# Altium schematic sheet sch1.SchDoc
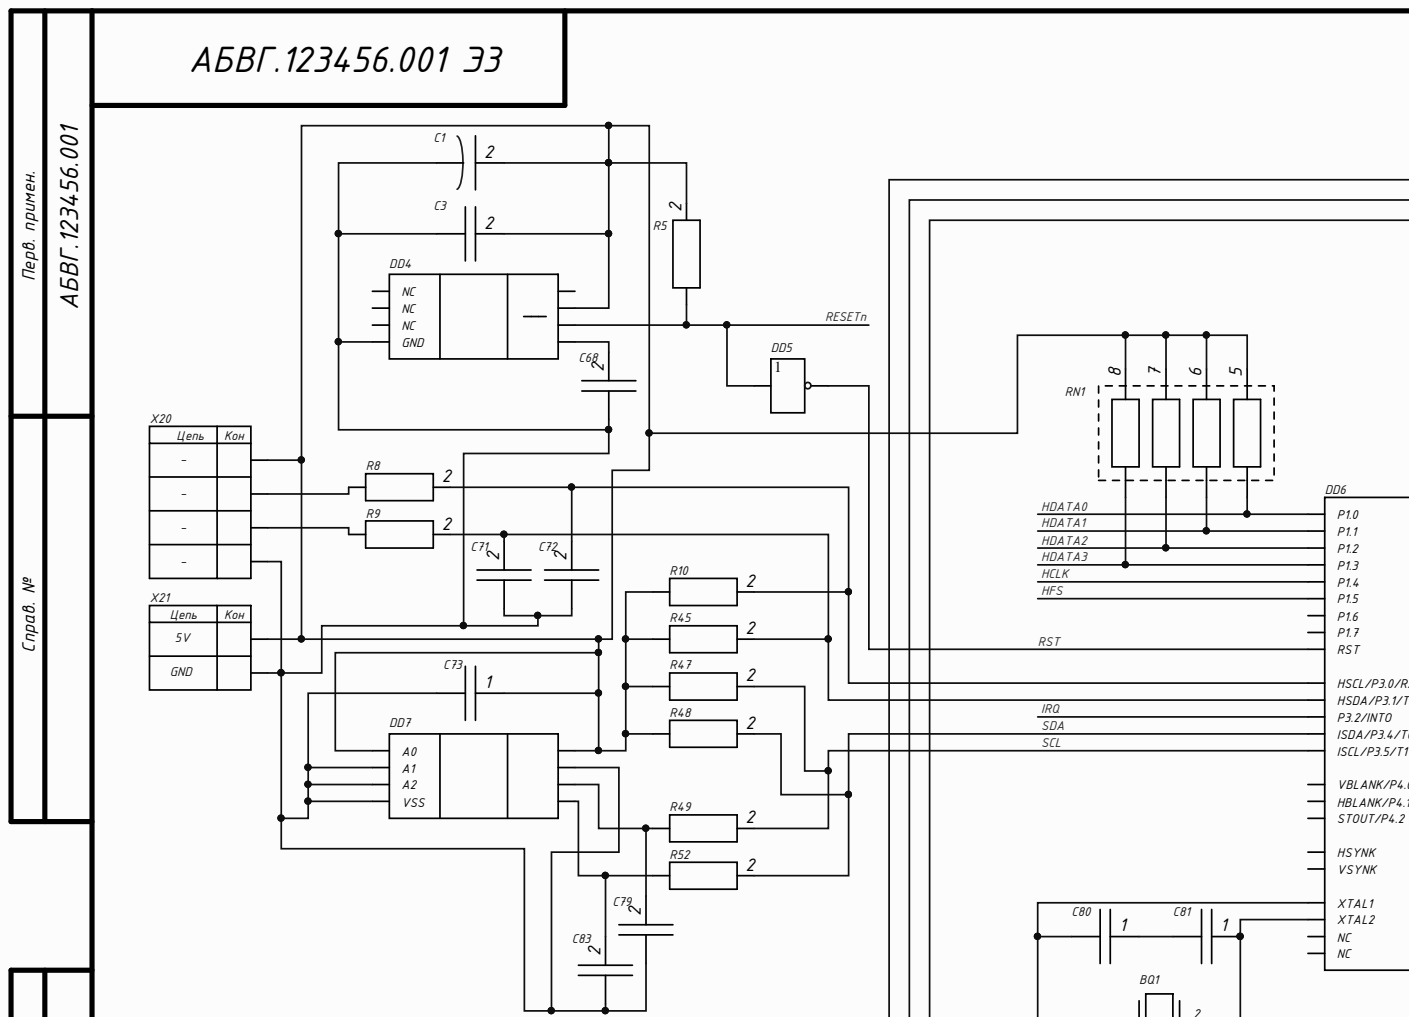
[diagram p0: sch1.SchDoc, top left]
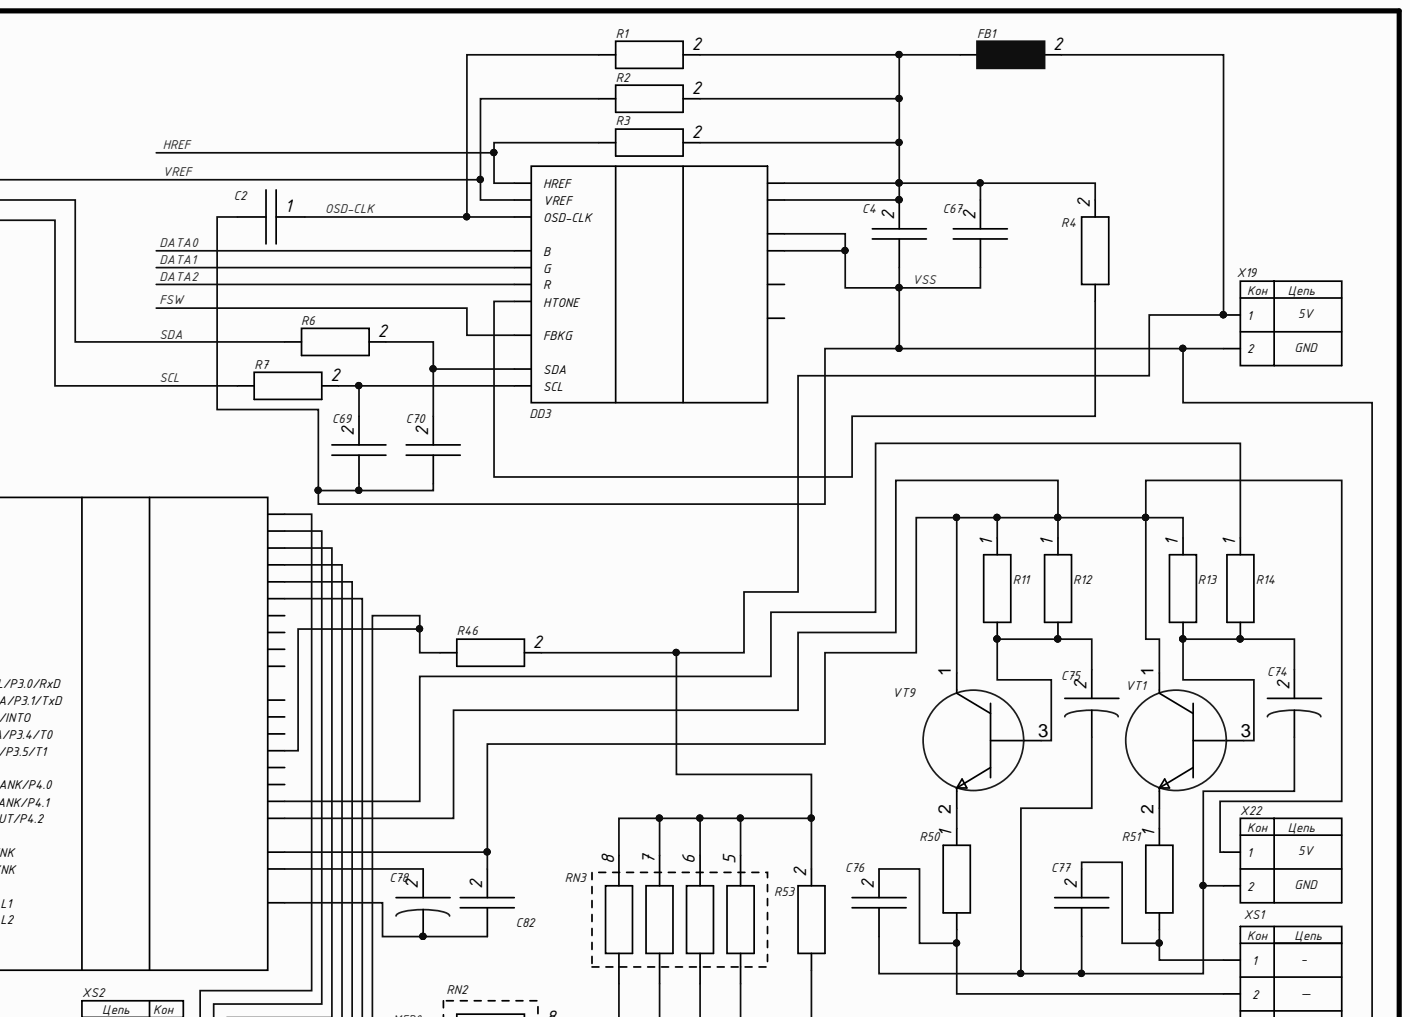
[diagram p1: sch1.SchDoc, top right]
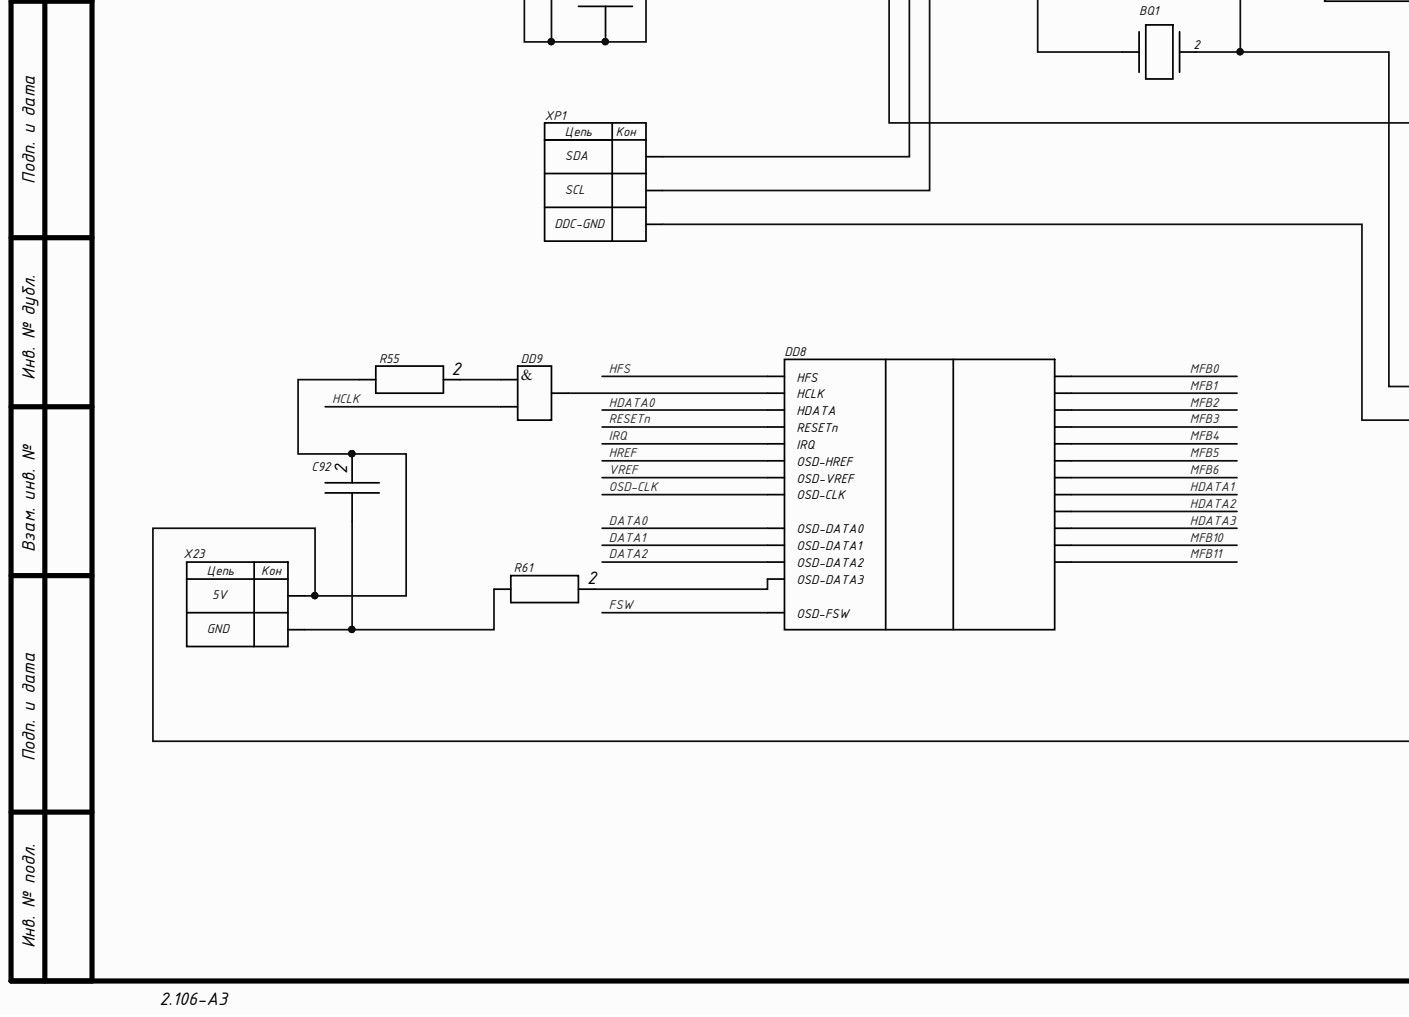
[diagram p2: sch1.SchDoc, bottom left]
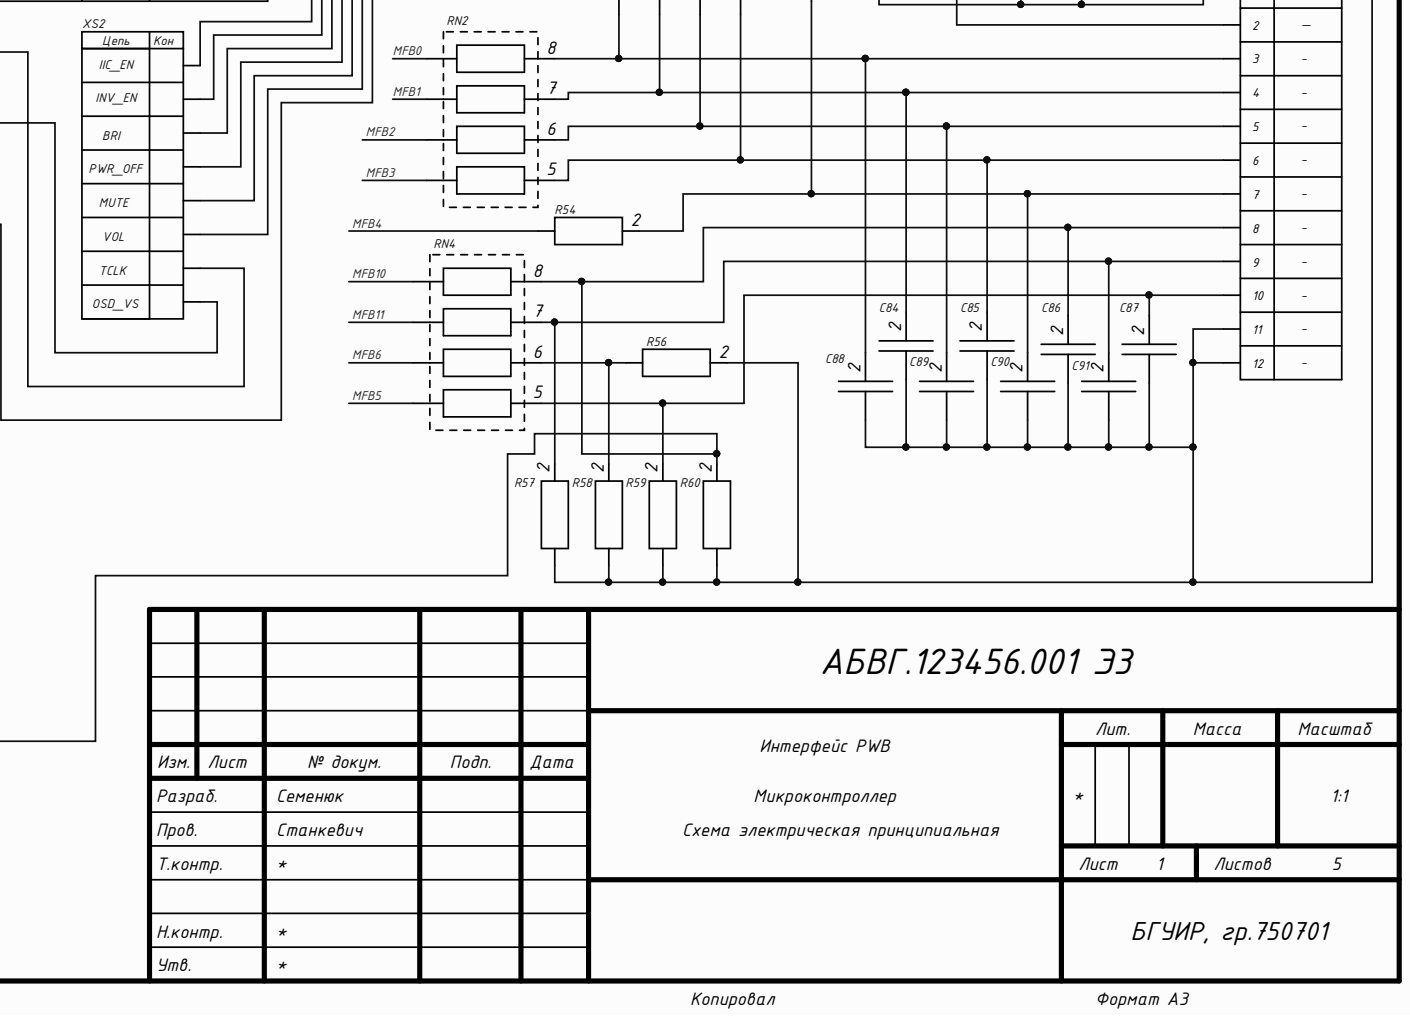
[diagram p3: sch1.SchDoc, bottom right]

## Parts
BQ1  Crystal  [Crystal]  (value not drawn)
C1  Capacitor Duga  [Capacitor 0805]  (value not drawn)
C2  Capacitor  [Capacitor 0805]  (value not drawn)
C3  Capacitor  [Capacitor 0805]  (value not drawn)
C4  Capacitor  [Capacitor 0805]  (value not drawn)
C67  Capacitor  [Capacitor 0805]  (value not drawn)
C68  Capacitor  [Capacitor 0805]  (value not drawn)
C69  Capacitor  [Capacitor 0805]  (value not drawn)
C70  Capacitor  [Capacitor 0805]  (value not drawn)
C71  Capacitor  [Capacitor 0805]  (value not drawn)
C72  Capacitor  [Capacitor 0805]  (value not drawn)
C73  Capacitor  [Capacitor 0805]  (value not drawn)
C74  Capacitor Duga  [Capacitor 0805]  (value not drawn)
C75  Capacitor Duga  [Capacitor 0805]  (value not drawn)
C76  Capacitor  [Capacitor 0805]  (value not drawn)
C77  Capacitor  [Capacitor 0805]  (value not drawn)
C78  Capacitor Duga  [Capacitor 0805]  (value not drawn)
C79  Capacitor  [Capacitor 0805]  (value not drawn)
C80  Capacitor  [Capacitor 0805]  (value not drawn)
C81  Capacitor  [Capacitor 0805]  (value not drawn)
C82  Capacitor  [Capacitor 0805]  (value not drawn)
C83  Capacitor  [Capacitor 0805]  (value not drawn)
C84  Capacitor  [Capacitor 0805]  (value not drawn)
C85  Capacitor  [Capacitor 0805]  (value not drawn)
C86  Capacitor  [Capacitor 0805]  (value not drawn)
C87  Capacitor  [Capacitor 0805]  (value not drawn)
C88  Capacitor  [Capacitor 0805]  (value not drawn)
C89  Capacitor  [Capacitor 0805]  (value not drawn)
C90  Capacitor  [Capacitor 0805]  (value not drawn)
C91  Capacitor  [Capacitor 0805]  (value not drawn)
C92  Capacitor  [Capacitor 0805]  (value not drawn)
DD3  I311  [I311]  (value not drawn)
DD4  M51953A  [M51953A]  (value not drawn)
DD5  Inverter  [Inverter]  (value not drawn)
DD6  MTV312M64  [MTV312M64]  (value not drawn)
DD7  24LC32  [24LC32]  (value not drawn)
DD8  gmZAN1  [GMZAN1]  (value not drawn)
DD9  Сonjuncture  [Conjuncture]  (value not drawn)
FB1  Ferrite_bead  [FERRITE BEAD]  (value not drawn)
R1  Resistor  [Resistor 1206]  (value not drawn)
R2  Resistor  [Resistor 1206]  (value not drawn)
R3  Resistor  [Resistor 1206]  (value not drawn)
R4  Resistor  [Resistor 1206]  (value not drawn)
R5  Resistor  [Resistor 1206]  (value not drawn)
R6  Resistor  [Resistor 1206]  (value not drawn)
R7  Resistor  [Resistor 1206]  (value not drawn)
R8  Resistor  [Resistor 1206]  (value not drawn)
R9  Resistor  [Resistor 1206]  (value not drawn)
R10  Resistor  [Resistor 1206]  (value not drawn)
R11  Resistor  [Resistor 1206]  (value not drawn)
R12  Resistor  [Resistor 1206]  (value not drawn)
R13  Resistor  [Resistor 1206]  (value not drawn)
R14  Resistor  [Resistor 1206]  (value not drawn)
R45  Resistor  [Resistor 1206]  (value not drawn)
R46  Resistor  [Resistor 1206]  (value not drawn)
R47  Resistor  [Resistor 1206]  (value not drawn)
R48  Resistor  [Resistor 1206]  (value not drawn)
R49  Resistor  [Resistor 1206]  (value not drawn)
R50  Resistor  [Resistor 1206]  (value not drawn)
R51  Resistor  [Resistor 1206]  (value not drawn)
R52  Resistor  [Resistor 1206]  (value not drawn)
R53  Resistor  [Resistor 1206]  (value not drawn)
R54  Resistor  [Resistor 1206]  (value not drawn)
R55  Resistor  [Resistor 1206]  (value not drawn)
R56  Resistor  [Resistor 1206]  (value not drawn)
R57  Resistor  [Resistor 1206]  (value not drawn)
R58  Resistor  [Resistor 1206]  (value not drawn)
R59  Resistor  [Resistor 1206]  (value not drawn)
R60  Resistor  [Resistor 1206]  (value not drawn)
R61  Resistor  [Resistor 1206]  (value not drawn)
RN1  RN  [RN]
RN2  RN  [RN]
RN3  RN  [RN]
RN4  RN  [RN]
VT1  Transistor 2_1  [Transistor 1]  (value not drawn)
VT9  Transistor 2_1  [Transistor 1]  (value not drawn)
X19  5V-GND_1  [VCC-GND]  (value not drawn)
X20  Header 4  [J102]  (value not drawn)
X21  5V-GND  [VCC-GND]  (value not drawn)
X22  5V-GND_1  [VCC-GND]  (value not drawn)
X23  5V-GND  [VCC-GND]  (value not drawn)
XP1  All pins_1  [All pins]  (value not drawn)
XS1  Header 12  [All pins]  (value not drawn)
XS2  All pins  [All pins]  (value not drawn)

## Nets
GND: DD5.3 DD9.3
VCC: DD5.5 DD9.5
DATA0: DD3.13(B) DD8.118(OSD-DATA0)
DATA1: DD3.14(G) DD8.119(OSD-DATA1)
DATA2: DD3.15(R) DD8.120(OSD-DATA2)
FSW: DD3.12(FBKG) DD8.122(OSD-FSW)
HCLK: DD6.22(P1.4) DD9.2
HDATA0: DD6.17(P1.0) DD8.99(HDATA) RN1.4
HDATA1: DD6.18(P1.1) DD8.105 RN1.3
HDATA2: DD6.20(P1.2) DD8.104 RN1.2
HDATA3: DD6.21(P1.3) DD8.102 RN1.1
HFS: DD6.23(P1.5) DD8.98(HFS)
HREF: DD3.5(HREF) DD8.115(OSD-HREF) R3.1
IRQ: DD6.19(P3.2/INTO) DD8.101(IRQ)
MFB0: DD8.113 RN2.1
MFB1: DD8.112 RN2.2
MFB2: DD8.111 RN2.3
MFB3: DD8.110 RN2.4
MFB4: DD8.109 R54.1
MFB5: DD8.107 RN4.4
MFB6: DD8.106 RN4.3
MFB10: DD8.124 RN4.1
MFB11: DD8.123 RN4.2
OSD-CLK: C2.1 DD3.2(OSD-CLK) DD8.117(OSD-CLK) R1.1
RESETn: DD4.6 DD5.2 DD8.100(RESETn) R5.1
RST: DD5.4 DD6.7(RST)
SCL: DD6.14(ISCL/P3.5/T1) R7.1 R47.2 R49.2 XP1.2
SDA: DD6.13(ISDA/P3.4/T0) R6.1 R48.2 R52.2 XP1.1
VREF: DD3.10(VREF) DD8.116(OSD-VREF) R2.1 XS2.8
VSS: C2.2 C4.1 C67.1 C69.1 C70.1 C84.1 C85.1 C86.1 C87.1 C88.1 C89.1 C90.1 C91.1 DD3.1 DD3.16 R56.2 R57.1 R58.1 R59.1 R60.1 X19.2 XS1.11 XS1.12
NetBQ1_1: BQ1.1 C80.2 DD6.12(XTAL1)
NetBQ1_2: BQ1.2 C81.1 DD6.11(XTAL2) XS2.7
NetC1_1: C1.1 C3.1 C68.1 C71.1 C72.1 C73.2 C79.1 C83.1 DD4.4(GND) DD7.2(A1) DD7.3(A2) DD7.4(VSS) DD7.7 X20.4 X21.2
NetC1_2: C1.2 C3.2 C73.1 DD4.7 DD7.1(A0) DD7.8 R5.2 R10.1 R45.1 R47.1 R48.1 RN1.5 RN1.6 RN1.7 RN1.8 X20.1 X21.1
NetC4_2: C4.2 C67.2 DD3.4 DD3.9 FB1.1 R1.2 R2.2 R3.2 R4.2
NetC68_2: C68.2 DD4.5
NetC69_2: C69.2 DD3.8(SCL) R7.2
NetC70_2: C70.2 DD3.7(SDA) R6.2
NetC71_2: C71.2 DD6.28(HSDA/P3.1/TxD) R9.2 R45.2
NetC72_2: C72.2 DD6.29(HSCL/P3.0/RxD) R8.2 R10.2
NetC74_1: C74.1 C75.1 C76.1 C77.1 X22.2
NetC74_2: C74.2 R13.2 R14.2 VT1.3
NetC75_2: C75.2 R11.2 R12.2 VT9.3
NetC76_2: C76.2 R50.2 XS1.2
NetC77_2: C77.2 R51.2 XS1.1
NetC78_1: C78.1 C82.1 DD6.10
NetC78_2: C78.2 DD6.4
NetC79_2: C79.2 DD7.6 R49.1
NetC80_1: C80.1 C81.2
NetC82_2: C82.2 DD6.8 DD6.33 R11.1 R12.1 R13.1 VT1.1 VT9.1 X22.1
NetC83_2: C83.2 DD7.5 R52.1
NetC84_2: C84.2 RN2.7 RN3.2 XS1.4
NetC85_2: C85.2 RN2.5 RN3.4 XS1.6
NetC86_2: C86.2 C92.2 R55.1 R60.2 RN4.8 X23.1 XS1.8
NetC87_2: C87.2 R59.2 RN4.5 XS1.10
NetC88_2: C88.2 RN2.8 RN3.1 XS1.3
NetC89_2: C89.2 RN2.6 RN3.3 XS1.5
NetC90_2: C90.2 R53.1 R54.2 XS1.7
NetC91_2: C91.2 R57.2 RN4.7 XS1.9
NetC92_1: C92.1 R61.1 X23.2
NetDD3_11: DD3.11(HTONE) R4.1
NetDD6_1: DD6.1 XS2.3
NetDD6_2: DD6.2 XS2.2
NetDD6_3: DD6.3 XS2.1
NetDD6_9: DD6.9 R46.1 XP1.3
NetDD6_32: DD6.32 R14.1
NetDD6_40: DD6.40 XS2.6
NetDD6_41: DD6.41 XS2.5
NetDD6_42: DD6.42 XS2.4
NetDD8_103: DD8.103(HCLK) DD9.4
NetDD8_121: DD8.121(OSD-DATA3) R61.2
NetDD9_1: DD9.1 R55.2
NetFB1_2: FB1.2 R46.2 R53.2 RN3.5 RN3.6 RN3.7 RN3.8 X19.1
NetR8_1: R8.1 X20.2
NetR9_1: R9.1 X20.3
NetR50_1: R50.1 VT9.2
NetR51_1: R51.1 VT1.2
NetR56_1: R56.1 R58.2 RN4.6

Not connected: DD3 3 6; DD4 1(NC) 2(NC) 3(NC) 8; DD6 5(NC) 6(NC) 15(STOUT/P4.2) 16 24(P1.6) 25(P1.7) 26 27 30 31 34 35 36(VBLANK/P4.0) 37(HBLANK/P4.1) 38 39 43(HSYNK) 44(VSYNK)

## Library data of the parts
BQ1 = Crystal: Component Kind: Standard; Library Name: sk7shared.SchLib
C1 C74 C75 C78 = Capacitor Duga: Component Kind: Standard; Library Name: sk7shared.SchLib
C2-C4 C67-C73 C76 C77 C79-C92 = Capacitor: Component Kind: Standard; Library Name: sk7shared.SchLib
DD3 = I311: Component Kind: Standard; Library Name: k7sch1.SchLib
DD4 = M51953A: Component Kind: Standard; Library Name: k7sch1.SchLib
DD5 = Inverter: Component Kind: Standard; Library Name: sk7shared.SchLib
DD6 = MTV312M64: Component Kind: Standard; Library Name: k7sch1.SchLib
DD7 = 24LC32: Component Kind: Standard; Library Name: k7sch1.SchLib
DD8 = gmZAN1: Component Kind: Standard; Library Name: k7sch1.SchLib
DD9 = Сonjuncture: Component Kind: Standard; Library Name: sk7shared.SchLib
FB1 = Ferrite_bead: Component Kind: Standard; Library Name: sk7shared.SchLib
R1-R14 R45-R61 = Resistor: Component Kind: Standard; Library Name: sk7shared.SchLib
RN1-RN4 = RN: Component Kind: Standard; Library Name: sk7shared.SchLib
VT1 VT9 = Transistor 2_1: Component Kind: Standard; Library Name: sk7shared.SchLib
X19 X22 = 5V-GND_1: Component Kind: Standard; Library Name: sk7shared.SchLib
X20 = Header 4: Component Kind: Standard; Library Name: k7sch3.SchLib
X21 X23 = 5V-GND: Component Kind: Standard; Library Name: sk7shared.SchLib
XP1 = All pins_1: Component Kind: Standard; Library Name: k7sch1.SchLib
XS1 = Header 12: Component Kind: Standard; Library Name: k7sch1.SchLib
XS2 = All pins: Component Kind: Standard; Library Name: k7sch1.SchLib
